# Altium schematic sheet 5_COM.SchDoc
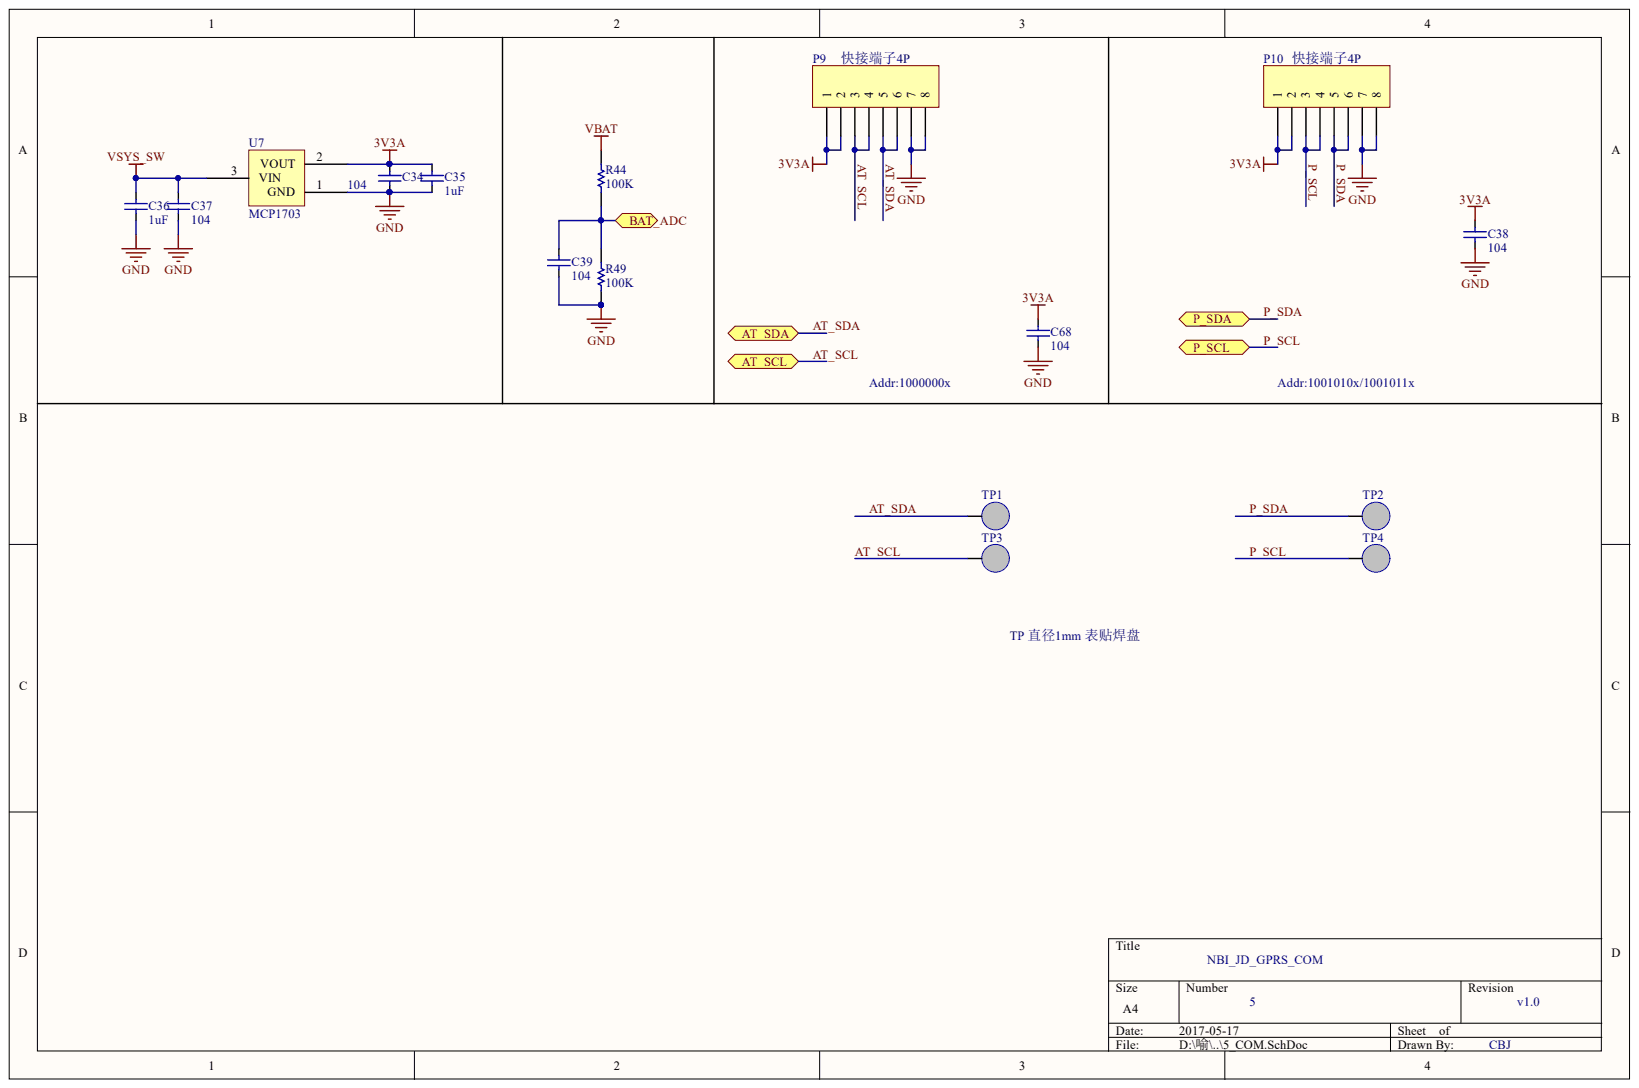
[diagram p0: 5_COM.SchDoc]

## Parts
C34  0.1uF  [0603]  (value not drawn)
C35  1uF  [0805]
C36  1uF  [0805]
C37  0.1uF  [0603]  (value not drawn)
C38  0.1uF  [0603]  (value not drawn)
C39  0.1uF  [0603]  (value not drawn)
C68  0.1uF  [0603]  (value not drawn)
P9  快接端子4P  [141R_4P]
P10  快接端子4P  [141R_4P]
R44  100K  [0603]
R49  100K  [0603]
TP1  TP1.0  [TP_D_cir1_00mm]  (value not drawn)
TP2  TP1.0  [TP_D_cir1_00mm]  (value not drawn)
TP3  TP1.0  [TP_D_cir1_00mm]  (value not drawn)
TP4  TP1.0  [TP_D_cir1_00mm]  (value not drawn)
U7  MCP1703  [SOT-23-A]

## Ports
AT_SCL, AT_SDA, BAT_ADC, P_SCL, P_SDA

## Nets
3V3A: C34.2 C35.2 C38.2 C68.2 P9.1 P9.2 P10.1 P10.2 U7.2
GND: C34.1 C35.1 C36.1 C37.1 C38.1 C39.1 C68.1 P9.7 P9.8 P10.7 P10.8 R49.2 U7.1
VBAT: R44.1
VSYS_SW: C36.2 C37.2 U7.3
AT_SCL: P9.3 P9.4 TP3.0 ; port AT_SCL
AT_SDA: P9.5 P9.6 TP1.0 ; port AT_SDA
BAT_ADC: C39.2 R44.2 R49.1 ; port BAT_ADC
P_SCL: P10.3 P10.4 TP4.0 ; port P_SCL
P_SDA: P10.5 P10.6 TP2.0 ; port P_SDA

## Library data of the parts
C34-C39 C68 = 0805C: Component Kind: Standard; Library Name: 2012机器人队库.IntLib
  C34 C37-C39 C68: : 104
  C35 C36: : 1uF
P9 P10 = Header 8: Header, 8-Pin; Component Kind: Standard; LatestRevisionDate: 17-Jul-2002; LatestRevisionNote: Re-released for DXP Platform.; Library Name: Miscellaneous Connectors.IntLib; Publisher: Altium Limited
R44 R49 = 0805R: : 100K; Component Kind: Standard; Library Name: 2012机器人队库.IntLib
TP1-TP4 = TP1.0: Component Kind: Standard; Library Name: LYC.SchLib
U7 = MCP1703: Component Kind: Standard; Library Name: 2012机器人队库.IntLib
